# Altium schematic sheet Sheet2.SchDoc
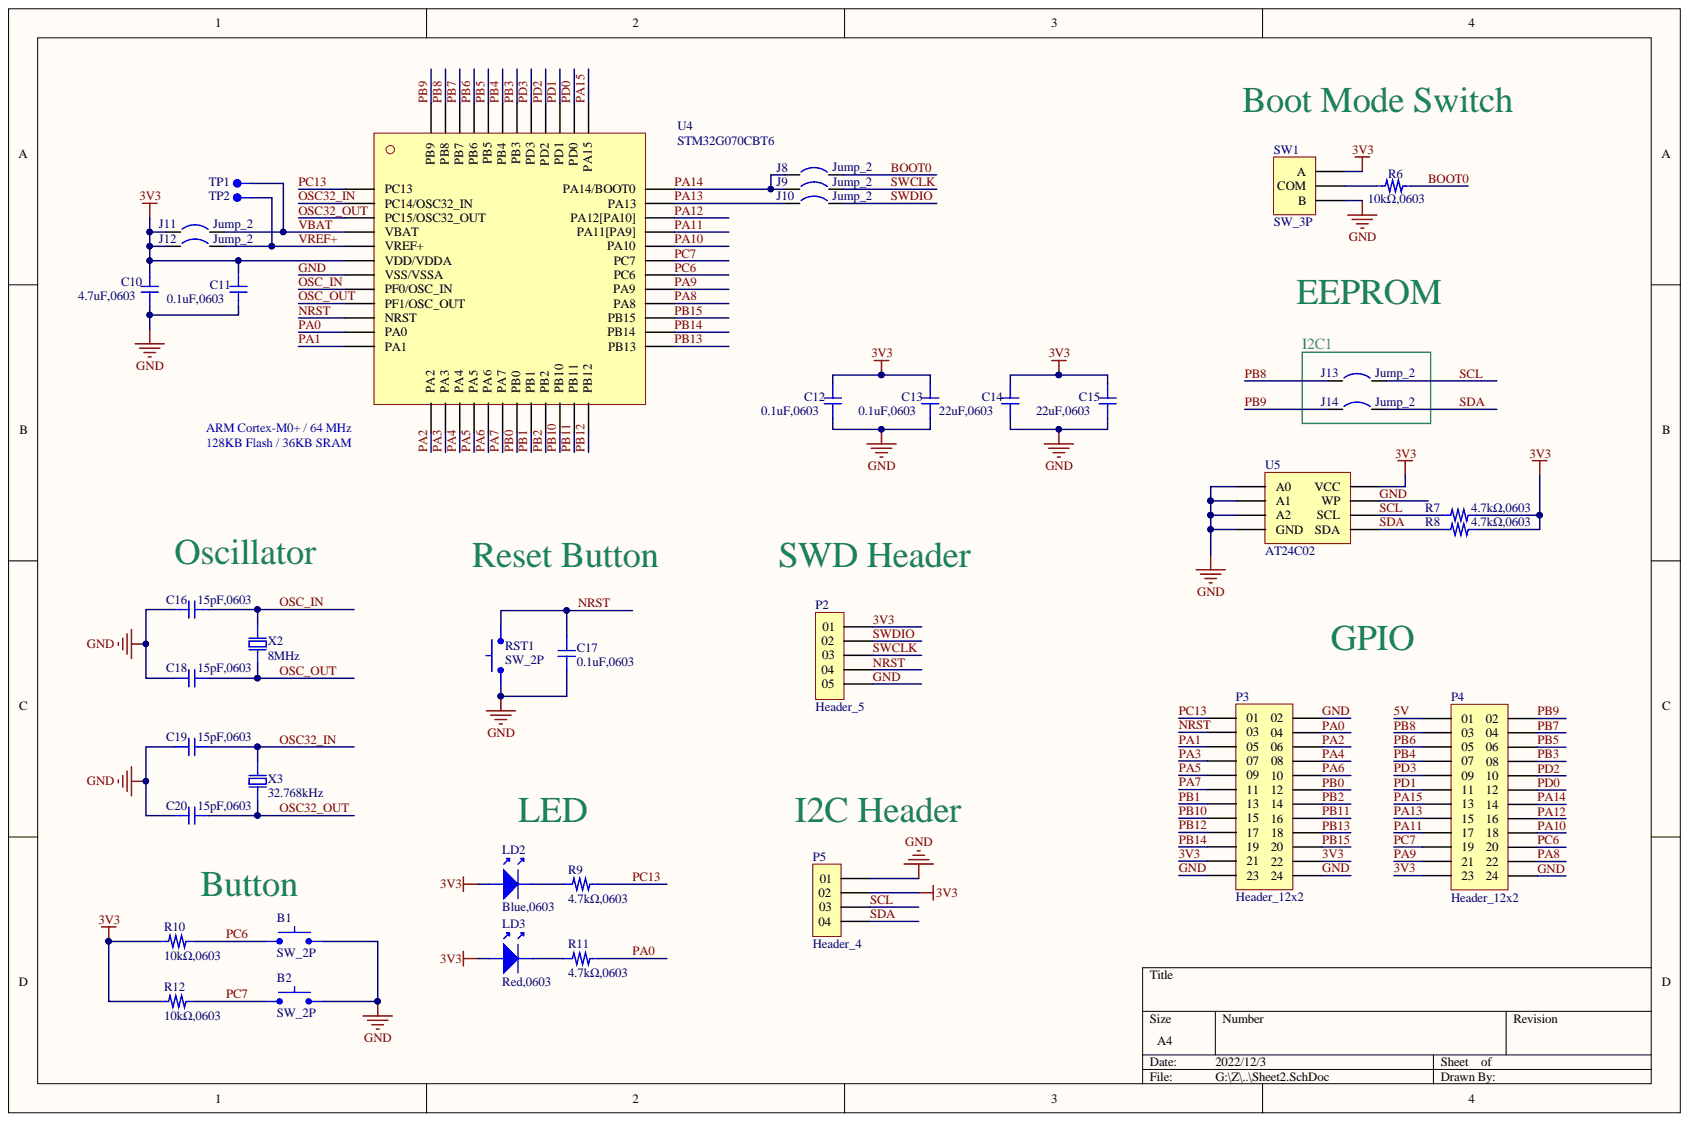
[diagram p0: Sheet2.SchDoc]

## Parts
B1  SW_2P  [SW_2P_3]
B2  SW_2P  [SW_2P_3]
C10  4.7uF,0603  [C0603]
C11  0.1uF,0603  [C0603]
C12  0.1uF,0603  [C0603]
C13  0.1uF,0603  [C0603]
C14  22uF,0603  [C0603]
C15  22uF,0603  [C0603]
C16  15pF,0603  [C0603]
C17  0.1uF,0603  [C0603]
C18  15pF,0603  [C0603]
C19  15pF,0603  [C0603]
C20  15pF,0603  [C0603]
J8  Jump_2  [Jp_small]
J9  Jump_2  [Jp_small]
J10  Jump_2  [Jp_small]
J11  Jump_2  [Jp_small]
J12  Jump_2  [Jp_small]
J13  Jump_2  [Jp_small]
J14  Jump_2  [Jp_small]
LD2  Blue,0603  [LED0603]
LD3  Red,0603  [LED0603]
P2  Header_5  [Header_5]
P3  Header_12x2  [Header_12x2_s]
P4  Header_12x2  [Header_12x2_s]
P5  Header_4  [Header_4]
R6  10kΩ,0603  [R0603]
R7  4.7kΩ,0603  [R0603]
R8  4.7kΩ,0603  [R0603]
R9  4.7kΩ,0603  [R0603]
R10  10kΩ,0603  [R0603]
R11  4.7kΩ,0603  [R0603]
R12  10kΩ,0603  [R0603]
RST1  SW_2P  [SW_2P_3]
SW1  SW_3P  [SW]
TP1  TP  [TP_1.5x1.5mm]
TP2  TP  [TP_1.5x1.5mm]
U4  STM32G070CBT6  [LQFP_48]
U5  AT24C02  [SOP8]
X2  8MHz  [XTAL_SMD_3225]
X3  32.768kHz  [XTAL_32.768KHz]

## Nets
3V3: C10.02 C11.02 C12.02 C13.02 C14.02 C15.02 J11.01 J12.01 LD2.01 LD3.01 P2.01 P3.21 P3.22 P4.23 P5.02 R7.02 R8.02 R10.01 R12.01 SW1.01 U4.06(VDD/VDDA) U5.08(VCC)
5V: P4.01
BOOT0: J8.02 R6.01
GND: B1.02 B2.02 C10.01 C11.01 C12.01 C13.01 C14.01 C15.01 C16.02 C17.01 C18.02 C19.02 C20.02 P2.05 P3.02 P3.23 P3.24 P4.24 P5.01 RST1.01 SW1.03 U4.07(VSS/VSSA) U5.01(A0) U5.02(A1) U5.03(A2) U5.04(GND) U5.07(WP)
NRST: C17.02 P2.04 P3.03 RST1.02 U4.10(NRST)
OSC32_IN: C19.01 U4.02(PC14/OSC32_IN) X3.02
OSC32_OUT: C20.01 U4.03(PC15/OSC32_OUT) X3.01
OSC_IN: C16.01 U4.08(PF0/OSC_IN) X2.02
OSC_OUT: C18.01 U4.09(PF1/OSC_OUT) X2.01
PA0: P3.04 R11.01 U4.11(PA0)
PA1: P3.05 U4.12(PA1)
PA2: P3.06 U4.13(PA2)
PA3: P3.07 U4.14(PA3)
PA4: P3.08 U4.15(PA4)
PA5: P3.09 U4.16(PA5)
PA6: P3.10 U4.17(PA6)
PA7: P3.11 U4.18(PA7)
PA8: P4.22 U4.28(PA8)
PA9: P4.21 U4.29(PA9)
PA10: P4.18 U4.32(PA10)
PA11: P4.17 U4.33(PA11[PA9])
PA12: P4.16 U4.34(PA12[PA10])
PA13: J10.01 P4.15 U4.35(PA13)
PA14: J8.01 J9.01 P4.14 U4.36(PA14/BOOT0)
PA15: P4.13 U4.37(PA15)
PB0: P3.12 U4.19(PB0)
PB1: P3.13 U4.20(PB1)
PB2: P3.14 U4.21(PB2)
PB3: P4.08 U4.42(PB3)
PB4: P4.07 U4.43(PB4)
PB5: P4.06 U4.44(PB5)
PB6: P4.05 U4.45(PB6)
PB7: P4.04 U4.46(PB7)
PB8: J13.01 P4.03 U4.47(PB8)
PB9: J14.01 P4.02 U4.48(PB9)
PB10: P3.15 U4.22(PB10)
PB11: P3.16 U4.23(PB11)
PB12: P3.17 U4.24(PB12)
PB13: P3.18 U4.25(PB13)
PB14: P3.19 U4.26(PB14)
PB15: P3.20 U4.27(PB15)
PC6: B1.01 P4.20 R10.02 U4.30(PC6)
PC7: B2.01 P4.19 R12.02 U4.31(PC7)
PC13: P3.01 R9.01 U4.01(PC13)
PD0: P4.12 U4.38(PD0)
PD1: P4.11 U4.39(PD1)
PD2: P4.10 U4.40(PD2)
PD3: P4.09 U4.41(PD3)
SCL: J13.02 P5.03 R7.01 U5.06(SCL)
SDA: J14.02 P5.04 R8.01 U5.05(SDA)
SWCLK: J9.02 P2.03
SWDIO: J10.02 P2.02
VBAT: J11.02 TP1.01 U4.04(VBAT)
VREF+: J12.02 TP2.01 U4.05(VREF+)
NetLD2_02: LD2.02 R9.02
NetLD3_02: LD3.02 R11.02
NetR6_02: R6.02 SW1.02

## Library data of the parts
C10-C20 = Cap: Component Kind: Standard; Library Name: myLib1.IntLib
LD2 LD3 = Diode - LED: Component Kind: Standard; Library Name: myLib1.IntLib
R6-R12 = Res: Component Kind: Standard; Library Name: myLib1.IntLib
X2 X3 = XTAL: Component Kind: Standard; Library Name: myLib1.IntLib
B1 B2 RST1 = SW_2P: Component Kind: Standard; Library Name: myLib1.IntLib
J8-J14 = Jump_2: Component Kind: Standard; Library Name: myLib1.IntLib
P2 = Header_5: Component Kind: Standard; Library Name: myLib1.IntLib
P3 P4 = Header_12x2: Component Kind: Standard; Library Name: myLib1.IntLib
P5 = Header_4: Component Kind: Standard; Library Name: myLib1.IntLib
SW1 = SW_3P: Component Kind: Standard; Library Name: myLib1.IntLib
TP1 TP2 = TP: Component Kind: Standard; Library Name: myLib1.IntLib
U4 = STM32G070CBT6: Component Kind: Standard; Library Name: myLib1.IntLib
U5 = AT24C02: Component Kind: Standard; Library Name: myLib1.IntLib
